# Altium schematic sheet 1_MICROCONTROLADOR.SchDoc
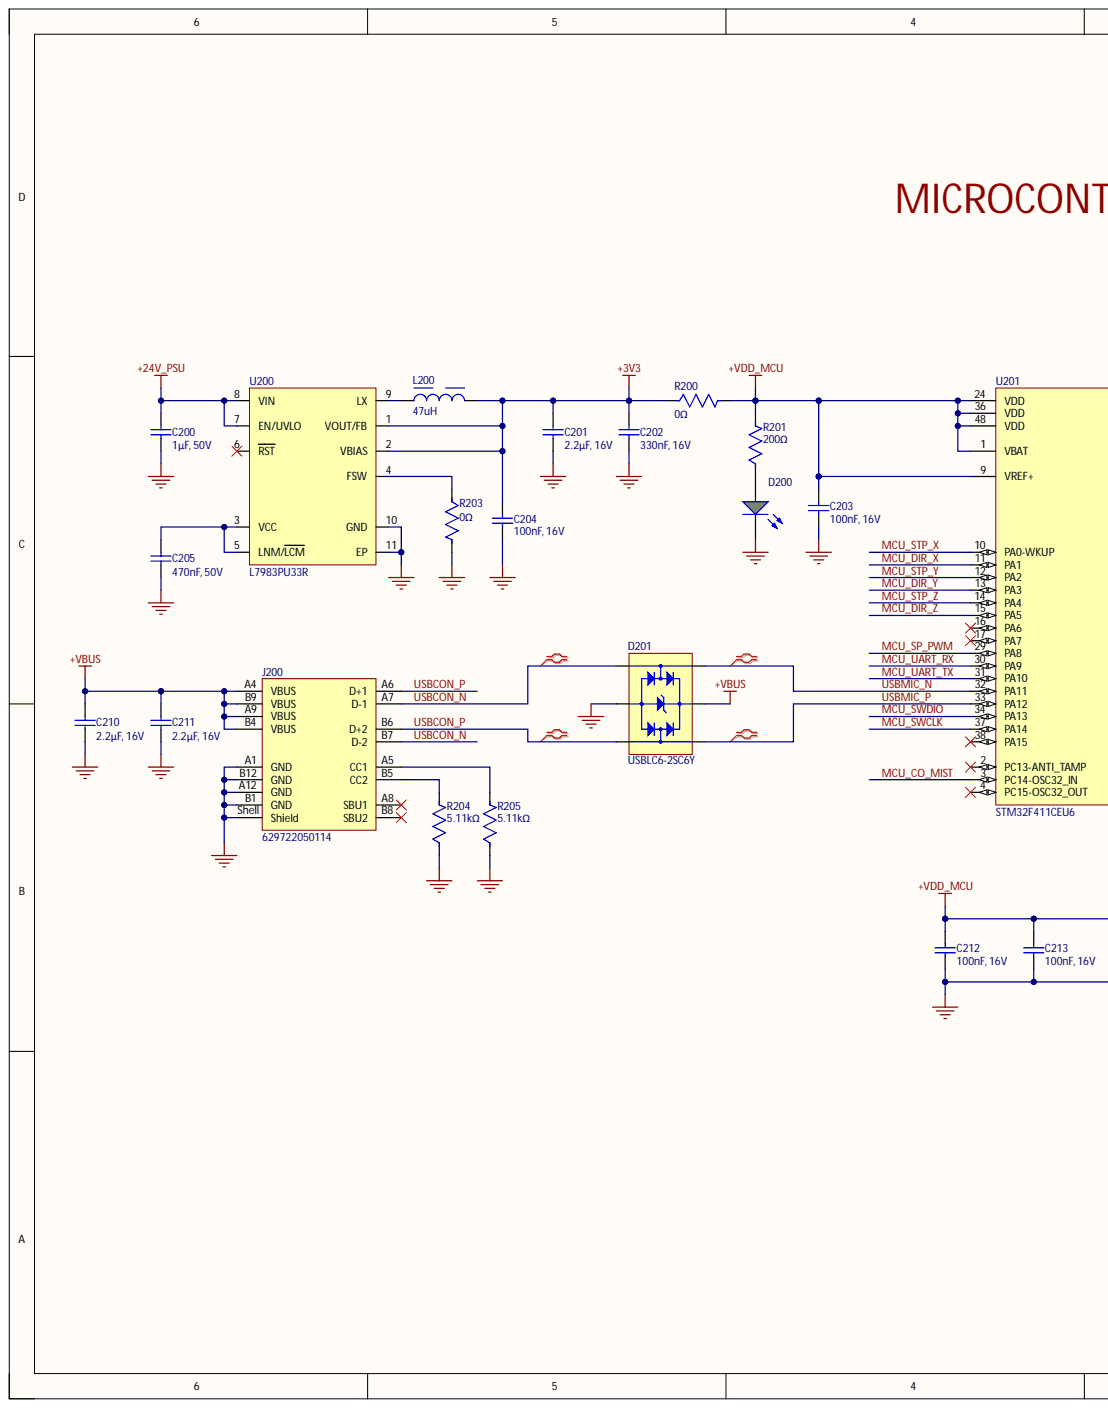
[diagram p0: 1_MICROCONTROLADOR.SchDoc, left]
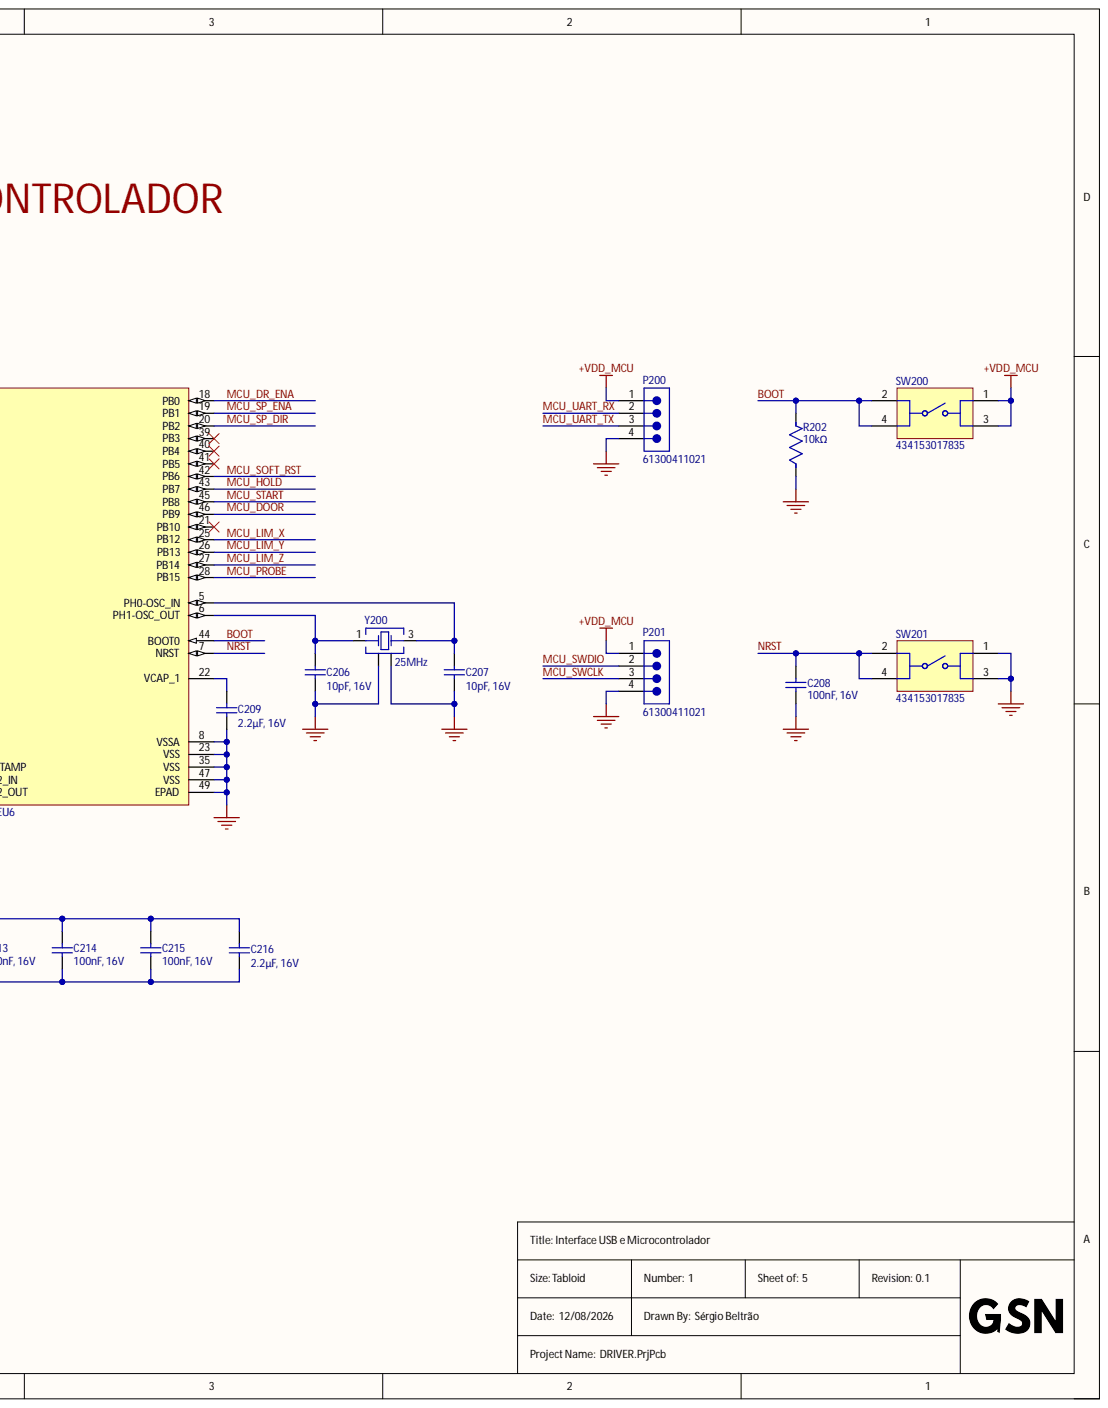
[diagram p1: 1_MICROCONTROLADOR.SchDoc, right]

## Parts
C200  1μF, 50V  Rated Voltage (DC): 50V  [WCAP-CSGP_0805_L2W1.25H1.25]
C201  2.2μF, 16V  [WCAP-CSGP_0603_L1.6W0.8H0.8_Wave]
C202  330nF, 16V  Rated Voltage (DC): 16V  [WCAP-CSGP_0603_L1.6W0.8H0.8_Wave]
C203  100nF, 16V  [WCAP-CSGP_0603_L1.6W0.8H0.8_Wave]
C204  100nF, 16V  [WCAP-CSGP_0603_L1.6W0.8H0.8_Wave]
C205  470nF, 50V  [WCAP-CSGP_0805_L2W1.25H1.25]
C206  10pF, 16V  Rated Voltage (DC): 16V  [WCAP-CSGP_0603_L1.6W0.8H0.8_Wave]
C207  10pF, 16V  Rated Voltage (DC): 16V  [WCAP-CSGP_0603_L1.6W0.8H0.8_Wave]
C208  100nF, 16V  [WCAP-CSGP_0603_L1.6W0.8H0.8_Wave]
C209  2.2μF, 16V  Rated Voltage (DC): 16V  [WCAP-CSGP_0603_L1.6W0.8H0.8_Wave]
C210  2.2μF, 16V  [WCAP-CSGP_0603_L1.6W0.8H0.8_Wave]
C211  2.2μF, 16V  Rated Voltage (DC): 16V  [WCAP-CSGP_0603_L1.6W0.8H0.8_Wave]
C212  100nF, 16V  [WCAP-CSGP_0603_L1.6W0.8H0.8_Wave]
C213  100nF, 16V  Rated Voltage (DC): 16V  [WCAP-CSGP_0603_L1.6W0.8H0.8_Wave]
C214  100nF, 16V  Rated Voltage (DC): 16V  [WCAP-CSGP_0603_L1.6W0.8H0.8_Wave]
C215  100nF, 16V  Rated Voltage (DC): 16V  [WCAP-CSGP_0603_L1.6W0.8H0.8_Wave]
C216  2.2μF, 16V  Rated Voltage (DC): 16V  [WCAP-CSGP_0603_L1.6W0.8H0.8_Wave]
D200  Bright Green, 2V, 140deg  [WL-SMCW_0603_150060xx75000]  (value not drawn)
D201  USBLC6-2SC6Y  [SOT-23-6]
J200  629722050114  [629722050114]
L200  47uH  [WE-MAIA_3015]
P200  61300411021  [61300411021]
P201  61300411021  [61300411021]
R200  0Ω  [0805-WAVE]
R201  200Ω  [0603-WAVE]
R202  10kΩ  [0603-WAVE]
R203  0Ω  [0603-WAVE]
R204  5.11kΩ  [0603-WAVE]
R205  5.11kΩ  [0603-WAVE]
SW200  434153017835  [434153017835]
SW201  434153017835  [434153017835]
U200  L7983PU33R  [10-WFDFN-EP]
U201  STM32F411CEU6  [UFQFN-48-7X7_EXPOSED_PAD]
Y200  25MHz  [WE-XTAL_IQXC-42]

## Nets
+3V3: C201.2 C202.2 C204.2 L200.2 R200.1 U200.1(VOUT/FB) U200.2(VBIAS)
+24V_PSU: C200.2 U200.7(EN/UVLO) U200.8(VIN)
+VBUS: C210.2 C211.2 D201.5 J200.A4(VBUS) J200.A9(VBUS) J200.B4(VBUS) J200.B9(VBUS)
+VDD_MCU: C203.2 C212.2 C213.2 C214.2 C215.2 C216.2 P200.1 P201.1 R200.2 R201.2 SW200.1 SW200.3 U201.1(VBAT) U201.9(VREF+) U201.24(VDD) U201.36(VDD) U201.48(VDD)
GND: C200.1 C201.1 C202.1 C203.1 C204.1 C205.1 C206.1 C207.1 C208.1 C209.1 C210.1 C211.1 C212.1 C213.1 C214.1 C215.1 C216.1 D200.1 D201.2 J200.A1(GND) J200.A12(GND) J200.B1(GND) J200.B12(GND) J200.Shell(Shield) P200.4 P201.4 R202.1 R203.2 R204.1 R205.1 SW201.1 SW201.3 U200.10(GND) U200.11(EP) U201.8(VSSA) U201.23(VSS) U201.35(VSS) U201.47(VSS) U201.49(EPAD) Y200.2 Y200.4
BOOT: R202.2 SW200.2 SW200.4 U201.44(BOOT0)
MCU_CO_MIST: U201.3(PC14-OSC32_IN)
MCU_DIR_X: U201.11(PA1)
MCU_DIR_Y: U201.13(PA3)
MCU_DIR_Z: U201.15(PA5)
MCU_DOOR: U201.46(PB9)
MCU_DR_ENA: U201.18(PB0)
MCU_HOLD: U201.43(PB7)
MCU_LIM_X: U201.25(PB12)
MCU_LIM_Y: U201.26(PB13)
MCU_LIM_Z: U201.27(PB14)
MCU_PROBE: U201.28(PB15)
MCU_SOFT_RST: U201.42(PB6)
MCU_SP_DIR: U201.20(PB2)
MCU_SP_ENA: U201.19(PB1)
MCU_SP_PWM: U201.29(PA8)
MCU_START: U201.45(PB8)
MCU_STP_X: U201.10(PA0-WKUP)
MCU_STP_Y: U201.12(PA2)
MCU_STP_Z: U201.14(PA4)
MCU_SWCLK: P201.3 U201.37(PA14)
MCU_SWDIO: P201.2 U201.34(PA13)
MCU_UART_RX: P200.2 U201.30(PA9)
MCU_UART_TX: P200.3 U201.31(PA10)
NRST: C208.2 SW201.2 SW201.4 U201.7(NRST)
USBCON_N: D201.1 J200.A7(D-1) J200.B7(D-2)
USBCON_P: D201.3 J200.A6(D+1) J200.B6(D+2)
USBMIC_N: D201.6 U201.32(PA11)
USBMIC_P: D201.4 U201.33(PA12)
NetC205_2: C205.2 U200.3(VCC) U200.5(LNM/LCM)
NetC206_2: C206.2 U201.6(PH1-OSC_OUT) Y200.1
NetC207_2: C207.2 U201.5(PH0-OSC_IN) Y200.3
NetC209_2: C209.2 U201.22(VCAP_1)
NetD200_2: D200.2 R201.1
NetJ200_A5: J200.A5(CC1) R205.2
NetJ200_B5: J200.B5(CC2) R204.2
NetL200_1: L200.1 U200.9(LX)
NetR203_1: R203.1 U200.4(FSW)

Not connected: J200 A8(SBU1) B8(SBU2); U200 6(RST); U201 2(PC13-ANTI_TAMP) 4(PC15-OSC32_OUT) 16(PA6) 17(PA7) 21(PB10) 38(PA15) 39(PB3) 40(PB4) 41(PB5)

## Library data of the parts
C200 = 885012207103R: WCAP-CSGP Multilayer Ceramic Chip Capacitor, General Purpose, size 0805, 1μF, 50V; Manufacturer: Wurth Elektronik; Capacitance: 1uF; Capacitance Tolerance: +/-10%; Case/Size Code: 0805; Ceramic Type: X7R; Database Table Name: Capacitors; Dissipation Factor: 10%; Insulation Resistance: 0.1Gohm; Technical Reference: X7R0805105K050DFCT20000; Category: Multilayer Ceramic Chip Capacitors; Component Kind: Standard; ComponentLink1Description: Website Link; ComponentLink1URL: https://www.we-online.com/redexpert/article/885012207103R?ad; ComponentLink2Description: Datasheet Link; ComponentLink2URL: https://www.we-online.com/redexpert/spec/885012207103R?ad; Height: 1.25mm; Length: 2mm; Library Name: WE - Passive Components.DbLib; Manufacturer Part Number: 885012207103R; Match Code: WCAP-CSGP; Mount: Surface Mount; Operating Temperature Max: 125degC; Operating Temperature Min: -55degC; Packaging: Tape and Reel; Simulation: 0805_885012207103R_1uF; Width: 1.25mm
C201 C209-C211 C216 = 885012106029: WCAP-CSGP Multilayer Ceramic Chip Capacitor, General Purpose, size 0603, 2.2μF, 16V; Manufacturer: Wurth Elektronik; Capacitance: 2.2uF; Capacitance Tolerance: +/-10%; Case/Size Code: 0603; Ceramic Type: X5R; Database Table Name: Capacitors; Dissipation Factor: 10%; Insulation Resistance: 0.05Gohm; Technical Reference: X5R0603225K016DFCT10000; Category: Multilayer Ceramic Chip Capacitors; Component Kind: Standard; ComponentLink1Description: Website Link; ComponentLink1URL: https://www.we-online.com/redexpert/article/885012106029?ad; ComponentLink2Description: Datasheet Link; ComponentLink2URL: https://www.we-online.com/redexpert/spec/885012106029?ad; Height: 0.8mm; Length: 1.6mm; Library Name: WE - Passive Components.DbLib; Manufacturer Part Number: 885012106029; Match Code: WCAP-CSGP; Mount: Surface Mount; Operating Temperature Max: 85degC; Operating Temperature Min: -55degC; Packaging: Tape and Reel; Simulation: 0603_885012106029_2.2uF; Width: 0.8mm
  C201 C210: Rated Voltage (DC): 16V
C202 = 885012206049: WCAP-CSGP Multilayer Ceramic Chip Capacitor, General Purpose, size 0603, 330nF, 16V; Manufacturer: Wurth Elektronik; Capacitance Tolerance: +/-10%; Case/Size Code: 0603; Ceramic Type: X7R; Database Table Name: Capacitors; Dissipation Factor: 5%; Insulation Resistance: 1.5Gohm; Technical Reference: X7R0603334K016DFCT10000; Capacitance: 330nF; Category: Multilayer Ceramic Chip Capacitors; Component Kind: Standard; ComponentLink1Description: Website Link; ComponentLink1URL: https://www.we-online.com/redexpert/article/885012206049?ad; ComponentLink2Description: Datasheet Link; ComponentLink2URL: https://www.we-online.com/redexpert/spec/885012206049?ad; Height: 0.8mm; Length: 1.6mm; Library Name: WE - Passive Components.DbLib; Manufacturer Part Number: 885012206049; Match Code: WCAP-CSGP; Mount: Surface Mount; Operating Temperature Max: 125degC; Operating Temperature Min: -55degC; Packaging: Tape and Reel; Simulation: 0603_885012206049_330nF; Width: 0.8mm
C203 C204 C208 C212-C215 = 885012206046: WCAP-CSGP Multilayer Ceramic Chip Capacitor, General Purpose, size 0603, 100nF, 16V; Manufacturer: Wurth Elektronik; Capacitance Tolerance: +/-10%; Case/Size Code: 0603; Ceramic Type: X7R; Database Table Name: Capacitors; Dissipation Factor: 3.5%; Insulation Resistance: 5Gohm; Technical Reference: X7R0603104K016DFCT10000; Capacitance: 100nF; Category: Multilayer Ceramic Chip Capacitors; Component Kind: Standard; ComponentLink1Description: Website Link; ComponentLink1URL: https://www.we-online.com/redexpert/article/885012206046?ad; ComponentLink2Description: Datasheet Link; ComponentLink2URL: https://www.we-online.com/redexpert/spec/885012206046?ad; Height: 0.8mm; Length: 1.6mm; Library Name: WE - Passive Components.DbLib; Manufacturer Part Number: 885012206046; Match Code: WCAP-CSGP; Mount: Surface Mount; Operating Temperature Max: 125degC; Operating Temperature Min: -55degC; Packaging: Tape and Reel; Simulation: 0603_885012206046_100nF; Width: 0.8mm
  C203 C204 C208 C212: Rated Voltage (DC): 16V
C205 = 885012207102: WCAP-CSGP Multilayer Ceramic Chip Capacitor, General Purpose, size 0805, 470nF, 50V; Manufacturer: Wurth Elektronik; Capacitance Tolerance: +/-10%; Case/Size Code: 0805; Ceramic Type: X7R; Database Table Name: Capacitors; Dissipation Factor: 3%; Insulation Resistance: 1.1Gohm; Technical Reference: X7R0805474K050DFCT10000; Capacitance: 470nF; Category: Multilayer Ceramic Chip Capacitors; Component Kind: Standard; ComponentLink1Description: Website Link; ComponentLink1URL: https://www.we-online.com/redexpert/article/885012207102?ad; ComponentLink2Description: Datasheet Link; ComponentLink2URL: https://www.we-online.com/redexpert/spec/885012207102?ad; Height: 1.25mm; Length: 2mm; Library Name: WE - Passive Components.DbLib; Manufacturer Part Number: 885012207102; Match Code: WCAP-CSGP; Mount: Surface Mount; Operating Temperature Max: 125degC; Operating Temperature Min: -55degC; Packaging: Tape and Reel; Rated Voltage (DC): 50V; Simulation: 0805_885012207102_470nF; Width: 1.25mm
C206 C207 = 885012006017: WCAP-CSGP Multilayer Ceramic Chip Capacitor, General Purpose, size 0603, 10pF, 16V; Manufacturer: Wurth Elektronik; Capacitance Tolerance: +/-5%; Case/Size Code: 0603; Ceramic Type: NP0; Database Table Name: Capacitors; Insulation Resistance: 10Gohm; Q Factor: 600; Technical Reference: NP00603100J016DFCT10000; Capacitance: 10pF; Category: Multilayer Ceramic Chip Capacitors; Component Kind: Standard; ComponentLink1Description: Website Link; ComponentLink1URL: https://www.we-online.com/redexpert/article/885012006017?ad; ComponentLink2Description: Datasheet Link; ComponentLink2URL: https://www.we-online.com/redexpert/spec/885012006017?ad; Height: 0.8mm; Length: 1.6mm; Library Name: WE - Passive Components.DbLib; Manufacturer Part Number: 885012006017; Match Code: WCAP-CSGP; Mount: Surface Mount; Operating Temperature Max: 125degC; Operating Temperature Min: -55degC; Packaging: Tape and Reel; Simulation: 0603_885012006017_10pF; Width: 0.8mm
D200 = 150060VS75000: WL-SMCW Mono-color Chip LED Waterclear, SMT, size 0603, Bright Green, 2V, 140°; Manufacturer: Wurth Elektronik; Case/Size Code: 0603; Chip Technology: AlInGaP; Database Table Name: LEDs; Dominant Wavelength: 570nm; Luminous Intensity: 40mcd; Peak Wavelength: 572nm; Category: Signal LEDs; Component Kind: Standard; ComponentLink1Description: Website Link; ComponentLink1URL: https://www.we-online.com/redexpert/article/150060VS75000?ad; ComponentLink2Description: Datasheet Link; ComponentLink2URL: https://www.we-online.com/redexpert/spec/150060VS75000?ad; Emitting Color: Bright Green; Forward Voltage: 2V; Height: 0.7mm; Length: 1.6mm; Library Name: WE - Optoelectronic Components.DbLib; Manufacturer Part Number: 150060VS75000; Match Code: WL-SMCW; Mount: Surface Mount; Operating Temperature Max: 85degC; Operating Temperature Min: -40degC; Packaging: Tape and Reel; Simulation: 0603_150060VS75000; Viewing Angle: 140deg; Width: 0.8mm
D201 = USBLC6-2SC6Y: TVS DIODE 5.25VWM 17VC SOT23-6; Manufacturer: STMicroelectronics; Breakdown Voltage: 6V; Clamping Voltage: 17V; Direction: Unidirectional; Element Configuration: Dual; ESD Protection: Yes; ESR: 330Ω; Forward Voltage: 860mV; Leakage Current: 150nA; Max Junction Temperature (Tj): 150°C; Number of Bidirectional Channels: 2; Number of Elements: 1; Operating Supply Voltage: 1.1V; Peak Pulse Current: 5A; Reverse Standoff Voltage: 5.25V; Test Current: 1mA; Value: 2.5pF; Case/Package: SOT-23-6; Category: TVS Diodes; China RoHS: Compliant; Component Kind: Standard; ComponentLink1Description: https://datasheet.octopart.com:443/USBLC6-2SC6Y-STMicroelectronics-datasheet-12533815.pdf?src-supplier=ODG+(Origin+Data+Global); ComponentLink1URL: https://datasheet.octopart.com:443/USBLC6-2SC6Y-STMicroelectronics-datasheet-12533815.pdf?src-supplier=ODG+(Origin+Data+Global); ComponentLink2Description: https://datasheet.octopart.com:443/USBLC6-2SC6Y-STMicroelectronics-datasheet-13733956.pdf?src-supplier=STMicroelectronics; ComponentLink2URL: https://datasheet.octopart.com:443/USBLC6-2SC6Y-STMicroelectronics-datasheet-13733956.pdf?src-supplier=STMicroelectronics; ComponentLink3Description: https://datasheet.octopart.com:443/USBLC6-2SC6Y-STMicroelectronics-datasheet-10412581.pdf?src-supplier=Factory+Futures; ComponentLink3URL: https://datasheet.octopart.com:443/USBLC6-2SC6Y-STMicroelectronics-datasheet-10412581.pdf?src-supplier=Factory+Futures; ComponentLink4Description: https://datasheet.octopart.com:443/USBLC6-2SC6Y-STMicroelectronics-datasheet-525477.pdf?src-supplier=Factory+Futures; ComponentLink4URL: https://datasheet.octopart.com:443/USBLC6-2SC6Y-STMicroelectronics-datasheet-525477.pdf?src-supplier=Factory+Futures; Depth: 1.75mm; Height: 1.45mm; Lead Free: Lead Free; Length: 3mm; Library Name: TVS-Diodes.SchLib; Lifecycle Status: Production; Manufacturer Part Number: USBLC6-2SC6Y; Max Operating Temperature: 150°C; Max Reverse Leakage Current: 150nA; Min Breakdown Voltage: 6V; Min Operating Temperature: -40°C; Mounting Technology: Surface Mount; Number of Channels: 2; Number of Terminals: 6; Packaging: Tape and Reel; Peak Reverse Current: 150nA; Pins: 6; Polarity: Unidirectional; Power Line Protection: Yes; Pricing: 1=0.46, 10=0.298, 100=0.211, 500=0.164, 1000=0.144 (USD); Radiation Hardening: No; REACH SVHC: Yes; RoHS: Compliant; Schedule B: 8536300000, 8536300000|8536300000|8536300000|8536300000|8536300000; Stock: 64605; Supplier: DigiKey; Supplier Part Number: 497-11882-1-ND; Termination: SMD/SMT; Width: 1.75mm
J200 = 629722050114: WR-COM USB 2.0 Vertical SMT; Manufacturer: Wurth Elektronik; Database Table Name: Connectors; Gender: Receptacle; Interface Type: Type C; Match Code: WR-USB; Number of Ports: 1; Rated Current: 5; Working Voltage: 48V (DC); Application: USB 2.0; Category: Input/Output Connector; Component Kind: Standard; ComponentLink1Description: Website Link; ComponentLink1URL: https://www.we-online.com; ComponentLink2Description: Datasheet Link; ComponentLink2URL: https://www.we-online.com/components/products/datasheet/629722050114; Library Name: WE - Electromechanical Components.DbLib; Manufacturer Part Number: 629722050114; Mount: Surface Mount; Number of Pins: 16; Operating Temperature Max: 85degC; Operating Temperature Min: -40degC; Orientation: Vertical; Packaging: Tape and Reel
L200 = 78438335470: WE-MAIA SMT Power Inductor, size 3015, 47uH, 0.5A, 2300mohm; Manufacturer: Wurth Elektronik; Case/Size Code: 3015; Database Table Name: Inductors; Saturation Current @ 10%: 0.75A; Saturation Current @ 30%: 1.5A; Self Resonant Frequency: 8MHz; Automotive: Yes; Category: Power Inductors; Component Kind: Standard; ComponentLink1Description: Website Link; ComponentLink1URL: https://www.we-online.com/redexpert/article/78438335470?ad; ComponentLink2Description: Datasheet Link; ComponentLink2URL: https://www.we-online.com/redexpert/spec/78438335470?ad; DC Resistance Max: 2300mohm; Height: 1.5mm; Inductance: 47uH; Length: 3mm; Library Name: WE - Passive Components.DbLib; Manufacturer Part Number: 78438335470; Match Code: WE-MAIA; Mount: Surface Mount; Operating Temperature Max: 125degC; Operating Temperature Min: -40degC; Packaging: Tape and Reel; Rated Current: 0.5A; Simulation: 3015_78438335470_47u; Width: 3mm
P200 P201 = 61300411021: WR-PHD Pin Header, THT, Angled, pitch 2.54mm, 1 Row, 4P; Manufacturer: Wurth Elektronik; Contact Resistance: 20mOhm; Database Table Name: Connectors; Rated Current: 3A; Withstanding Voltage: 500V; Working Voltage: 250V; Category: Board-to-Board Connectors; Component Kind: Standard; ComponentLink1Description: Website Link; ComponentLink1URL: https://www.we-online.com/redexpert/article/61300411021?ad; ComponentLink2Description: Datasheet Link; ComponentLink2URL: https://www.we-online.com/redexpert/spec/61300411021?ad; Gender: Pin Header; Lead Pitch: 2.54mm; Library Name: WE - Electromechanical Components.DbLib; Manufacturer Part Number: 61300411021; Match Code: WR-PHD; Mount: Through Hole; Number of Pins: 4; Number of Rows: 1; Operating Temperature Max: 105degC; Operating Temperature Min: -40degC; Orientation: Angled; Packaging: Bag
R200 = RC0805FR-070RL: RES 0 OHM 1% 1/8W 0805; Manufacturer: Yageo Group; Power Dissipation: 125mW; Temperature Coefficient: 100ppm/°C; Voltage Rating: 150V; Case/Package: 0805; Category: Chip SMD Resistors; China RoHS: Non-Compliant; Component Kind: Standard; ComponentLink1Description: https://datasheet.octopart.com:443/RC0805FR-070RL-Yageo-datasheet-127823281.pdf?src-supplier=Upverter; ComponentLink1URL: https://datasheet.octopart.com:443/RC0805FR-070RL-Yageo-datasheet-127823281.pdf?src-supplier=Upverter; ComponentLink2Description: https://datasheet.octopart.com:443/RC0805FR-070RL-Yageo-datasheet-17879891.pdf?src-supplier=IHS; ComponentLink2URL: https://datasheet.octopart.com:443/RC0805FR-070RL-Yageo-datasheet-17879891.pdf?src-supplier=IHS; ComponentLink3Description: https://datasheet.octopart.com:443/RC0805FR-070RL-Yageo-datasheet-8330313.pdf?src-supplier=Burklin+Elektronik; ComponentLink3URL: https://datasheet.octopart.com:443/RC0805FR-070RL-Yageo-datasheet-8330313.pdf?src-supplier=Burklin+Elektronik; ComponentLink4Description: https://datasheet.octopart.com:443/RC0805FR-070RL-Yageo-datasheet-164759695.pdf?src-supplier=Future+Electronics; ComponentLink4URL: https://datasheet.octopart.com:443/RC0805FR-070RL-Yageo-datasheet-164759695.pdf?src-supplier=Future+Electronics; ComponentLink5Description: https://datasheet.octopart.com:443/RC0805FR-070RL-Yageo-datasheet-12592811.pdf?src-supplier=Future+Electronics; ComponentLink5URL: https://datasheet.octopart.com:443/RC0805FR-070RL-Yageo-datasheet-12592811.pdf?src-supplier=Future+Electronics; ComponentLink6Description: https://datasheet.octopart.com:443/RC0805FR-070RL-Yageo-datasheet-8324252.pdf?src-supplier=Future+Electronics; ComponentLink6URL: https://datasheet.octopart.com:443/RC0805FR-070RL-Yageo-datasheet-8324252.pdf?src-supplier=Future+Electronics; ComponentLink7Description: https://datasheet.octopart.com:443/RC0805FR-070RL-Yageo-datasheet-141265859.pdf?src-supplier=TME; ComponentLink7URL: https://datasheet.octopart.com:443/RC0805FR-070RL-Yageo-datasheet-141265859.pdf?src-supplier=TME; ComponentLink8Description: https://datasheet.octopart.com/RC0805FR-070RL-Yageo-datasheet-73766026.pdf; ComponentLink8URL: https://datasheet.octopart.com/RC0805FR-070RL-Yageo-datasheet-73766026.pdf; ComponentLink9Description: http://sigma.octopart.com:80/conflict_mineral_statement/Yageo-company-3.pdf?src-supplier=Compliance+Statements; ComponentLink9URL: http://sigma.octopart.com:80/conflict_mineral_statement/Yageo-company-3.pdf?src-supplier=Compliance+Statements; ComponentLink10Description: http://sigma.octopart.com:80/rohs_statement/Yageo-company-2.pdf?src-supplier=Compliance+Statements; ComponentLink10URL: http://sigma.octopart.com:80/rohs_statement/Yageo-company-2.pdf?src-supplier=Compliance+Statements; Composition: Thick Film; Contact Plating: Tin; Depth: 1.25mm; Height: 500µm; Lead Free: Lead Free; Length: 2mm; Library Name: Resistors-0805-E96-Yageo.SchLib; Lifecycle Status: Production; Manufacturer Part Number: RC0805FR-070RL; Max Operating Temperature: 155°C; Military Standard: Not; Min Operating Temperature: -55°C; Mounting Technology: Surface Mount; Number of Terminals: 2; Power Rating: 125mW; Pricing: 1=0.1, 10=0.017, 25=0.0144, 50=0.0124, 100=0.0109, 250=0.00916, 500=0.00808, 1000=0.00715 (USD); Radiation Hardening: No; REACH SVHC: Yes; RoHS: Compliant; Stock: 748818; Supplier: DigiKey; Supplier Part Number: 311-0.0CRCT-ND; Tolerance: 1%; Value: 0Ω; Width: 1.25mm
R201 = RC0603FR-07200RL: RES 200 OHM 1% 1/10W 0603; Manufacturer: Yageo Group; Max Power Dissipation: 100mW; Temperature Coefficient: ±200ppm/°C; Voltage Rating: 75V; Voltage Rating (AC): 50V; Case Code (Imperial): 0603; Case Code (Metric): 1608; Case/Package: 0603; Category: Chip SMD Resistors; China RoHS: Non-Compliant; Component Kind: Standard; Component Link Description: https://www.yageogroup.com/content/datasheet/asset/file/PYU-RC_GROUP_51_ROHS_L; Component Link URL: https://www.yageogroup.com/content/datasheet/asset/file/PYU-RC_GROUP_51_ROHS_L; Composition: Thick Film; Contact Plating: Tin; Datasheet: https://www.yageogroup.com/content/datasheet/asset/file/PYU-RC_GROUP_51_ROHS_L; Depth: 800µm; Features: Moisture Resistant; Height: 450µm; Lead Free: Lead Free; Length: 1.6mm; Library Name: Resistors-0603-E96-Yageo.SchLib; Lifecycle Status: Production; Manufacturer Part Number: RC0603FR-07200RL; Max Operating Temperature: 155°C; Military Standard: Not; Min Operating Temperature: -55°C; Mounting Technology: Surface Mount; Number of Terminals: 2; Number of Terminations: 2; Packaging: Tape & Reel; Power Dissipation: 100mW; Power Rating: 100mW; Radiation Hardening: No; REACH SVHC: Yes; RoHS: Compliant; Supplier: DigiKey; Supplier Part Number: 311-200HRCT-ND; Termination: SMD/SMT; Tolerance: 1%; Value: 200Ω; Voltage Rating (DC): 50V; Weight: 2.012816mg; Width: 800µm; Working Voltage: 75V
R202 = RC0603FR-0710KL: RES 10K OHM 1% 1/10W 0603; Manufacturer: Yageo Group; Max Power Dissipation: 100mW; Temperature Coefficient: ±200ppm/°C; Voltage Rating: 75V; Voltage Rating (AC): 50V; Case Code (Imperial): 0603; Case Code (Metric): 1608; Case/Package: 0603; Category: Chip SMD Resistors; China RoHS: Non-Compliant; Component Kind: Standard; Component Link Description: https://www.yageogroup.com/content/datasheet/asset/file/PYU-RC_GROUP_51_ROHS_L; Component Link URL: https://www.yageogroup.com/content/datasheet/asset/file/PYU-RC_GROUP_51_ROHS_L; Composition: Thick Film; Contact Plating: Tin; Datasheet: https://www.yageogroup.com/content/datasheet/asset/file/PYU-RC_GROUP_51_ROHS_L; Depth: 800µm; Features: Moisture Resistant; Height: 450µm; Lead Free: Lead Free; Length: 1.6mm; Library Name: Resistors-0603-E96-Yageo.SchLib; Lifecycle Status: Production; Manufacturer Part Number: RC0603FR-0710KL; Max Operating Temperature: 155°C; Military Standard: Not; Min Operating Temperature: -55°C; Mounting Technology: Surface Mount; Number of Terminals: 2; Number of Terminations: 2; Packaging: Tape & Reel; Power Dissipation: 100mW; Power Rating: 100mW; Radiation Hardening: No; REACH SVHC: Yes; RoHS: Compliant; Supplier: DigiKey; Supplier Part Number: 311-10.0KHRCT-ND; Termination: SMD/SMT; Tolerance: 1%; Value: 10kΩ; Voltage Rating (DC): 50V; Weight: 2.012816mg; Width: 800µm; Working Voltage: 75V
R203 = RC0603FR-070RL: RES 0 OHM 1% 1/10W 0603; Manufacturer: Yageo Group; Max Power Dissipation: 100mW; Temperature Coefficient: ±200ppm/°C; Voltage Rating: 75V; Voltage Rating (AC): 50V; Case Code (Imperial): 0603; Case Code (Metric): 1608; Case/Package: 0603; Category: Chip SMD Resistors; China RoHS: Non-Compliant; Component Kind: Standard; Component Link Description: https://www.yageogroup.com/content/datasheet/asset/file/PYU-RC_GROUP_51_ROHS_L; Component Link URL: https://www.yageogroup.com/content/datasheet/asset/file/PYU-RC_GROUP_51_ROHS_L; Composition: Thick Film; Contact Plating: Tin; Datasheet: https://www.yageogroup.com/content/datasheet/asset/file/PYU-RC_GROUP_51_ROHS_L; Depth: 800µm; Features: Moisture Resistant; Height: 450µm; Lead Free: Lead Free; Length: 1.6mm; Library Name: Resistors-0603-E96-Yageo.SchLib; Lifecycle Status: Production; Manufacturer Part Number: RC0603FR-070RL; Max Operating Temperature: 155°C; Military Standard: Not; Min Operating Temperature: -55°C; Mounting Technology: Surface Mount; Number of Terminals: 2; Number of Terminations: 2; Packaging: Tape & Reel; Power Dissipation: 100mW; Power Rating: 100mW; Radiation Hardening: No; REACH SVHC: Yes; RoHS: Compliant; Supplier: DigiKey; Supplier Part Number: 311-0.0HRCT-ND; Termination: SMD/SMT; Tolerance: 1%; Value: 0Ω; Voltage Rating (DC): 50V; Weight: 2.012816mg; Width: 800µm; Working Voltage: 75V
R204 R205 = RC0603FR-075K11L: RES 5.11K OHM 1% 1/10W 0603; Manufacturer: Yageo Group; Max Power Dissipation: 100mW; Temperature Coefficient: ±200ppm/°C; Voltage Rating: 75V; Voltage Rating (AC): 50V; Case Code (Imperial): 0603; Case Code (Metric): 1608; Case/Package: 0603; Category: Chip SMD Resistors; China RoHS: Non-Compliant; Component Kind: Standard; Component Link Description: https://www.yageogroup.com/content/datasheet/asset/file/PYU-RC_GROUP_51_ROHS_L; Component Link URL: https://www.yageogroup.com/content/datasheet/asset/file/PYU-RC_GROUP_51_ROHS_L; Composition: Thick Film; Contact Plating: Tin; Datasheet: https://www.yageogroup.com/content/datasheet/asset/file/PYU-RC_GROUP_51_ROHS_L; Depth: 800µm; Features: Moisture Resistant; Height: 450µm; Lead Free: Lead Free; Length: 1.6mm; Library Name: Resistors-0603-E96-Yageo.SchLib; Lifecycle Status: Production; Manufacturer Part Number: RC0603FR-075K11L; Max Operating Temperature: 155°C; Military Standard: Not; Min Operating Temperature: -55°C; Mounting Technology: Surface Mount; Number of Terminals: 2; Number of Terminations: 2; Packaging: Tape & Reel; Power Dissipation: 100mW; Power Rating: 100mW; Radiation Hardening: No; REACH SVHC: Yes; RoHS: Compliant; Supplier: DigiKey; Supplier Part Number: 311-5.11KHRCT-ND; Termination: SMD/SMT; Tolerance: 1%; Value: 5.11kΩ; Voltage Rating (DC): 50V; Weight: 2.012816mg; Width: 800µm; Working Voltage: 75V
SW200 SW201 = 434153017835: WS-TASV J-Bend SMT Tact Switch, 3.5mm x 2.9mm x 1.7mm, SPST-NO, 350gf, 12V, 50mA; Manufacturer: Wurth Elektronik; Database Table Name: Switches; Washable: No; Category: Tact Switch; Circuit: SPST-NO; Component Kind: Standard; ComponentLink1Description: Website Link; ComponentLink1URL: https://www.we-online.com; ComponentLink2Description: Datasheet Link; ComponentLink2URL: https://www.we-online.com/components/products/datasheet/434153017835; Contact Material: Stainless Steel; Contact Plating: Silver; Height: 1.7mm; Length: 3.5mm; Library Name: WE - Electromechanical Components.DbLib; Manufacturer Part Number: 434153017835; Match Code: WS-TASV; Mount: Surface Mount; Operating Temperature Max: 85degC; Operating Temperature Min: -40degC; Operation Force: 350gf; Packaging: Tape and Reel; Rated Current: 50mA; Rated Voltage (DC): 12V; Terminal Material: Copper Alloy; Terminal Plating: Silver; Width: 2.9mm
U200 = L7983PU33R: IC REG BUCK 3.3V 300MA 10DFN; Manufacturer: STMicroelectronics; Max Output Voltage: 60V; Max Switching Frequency: 2.42MHz; Min Input Voltage: 3.5V; Min Output Voltage: 850mV; Nominal Supply Voltage: 24V; Number of Circuits: 1; Quiescent Current: 10µA; Synchronous Rectifier: Yes; Category: Voltage Regulators - Switching; China RoHS: Compliant; Component Kind: Standard; Country of Origin: Mainland China; Export Control Classification Number (ECCN) Code: EAR99; Harmonized Tariff Schedule (HTS) Code: 8542.39.00.60; Height - Seated (Max): 800µm; Introduction Date: 2020-10-06; Length: 3mm; Library Name: Voltage-Regulators.SchLib; Lifecycle Status: Production; Manufacturer Part Number: L7983PU33R; Max Operating Temperature: 150°C; Max Output current: 300mA; Max Supply Voltage: 60V; Min Operating Temperature: -40°C; Nominal Output Voltage: 3.3V; Number of Terminals: 10; Output Current: 300mA; Packaging: Tape and Reel; Pricing: 1=2.69, 10=2.001, 25=1.83, 100=1.6411, 250=1.55108, 500=1.5155 (USD); REACH SVHC: Yes; RoHS: Compliant; Schedule B: 8542390000, 8542390000|8542390000|8542390000|8542390000|8542390000|8542390000|8542390000|8542390000|8542390000|8542390000|8542390000|8542390000|8542390000|8542390000|8542390000|8542390000|8542390000|8542390000|8542390000|8542390000|8542390000|8542390000|8542390000|85; Stock: 4366; Supplier: DigiKey; Supplier Part Number: 497-L7983PU33RCT-ND; Terminal Pitch: 500µm; Topology: Buck; Width: 3mm
U201 = STM32F411CEU6: IC MCU 32BIT 512KB FLSH 48UFQFPN; Manufacturer: STMicroelectronics; ADC Channels (Resolution): 10-Ch 12-Bit; Clock Frequency: 26MHz; Core Architecture: ARM Cortex-M4; Data Bus Width: 32b; EEPROM Memory Size: 0B; Frequency: 100MHz; Interface: SDIO, USB; Max Power Dissipation: 625mW; Max Supply Current: 34.5mA; Max Supply Voltage: 3.6V; Memory Format: FLASH; Min Supply Voltage: 1.7V; Nominal Supply Current: 1.8µA; Number of A/D Converters: 1; Number of ADC Channels: 16; Number of Channels: 10; Number of I2C Channels: 3; Number of I/Os: 36; Number of SPI Channels: 5; Number of Timers/Counters: 2; Operating Supply Voltage: 3.3V; Oscillator Type: Internal; Peripherals: POR; RAM Size: 128kB; Watchdog Timer: Yes; Case/Package: UFQFPN; Category: Microcontrollers; China RoHS: Compliant; Component Kind: Standard; ComponentLink1Description: https://datasheet.octopart.com:443/STM32F411CEU6-STMicroelectronics-datasheet-41919523.pdf?src-supplier=element14+APAC; ComponentLink1URL: https://datasheet.octopart.com:443/STM32F411CEU6-STMicroelectronics-datasheet-41919523.pdf?src-supplier=element14+APAC; ComponentLink2Description: https://datasheet.octopart.com:443/STM32F411CEU6-STMicroelectronics-datasheet-27102711.pdf?src-supplier=Upverter; ComponentLink2URL: https://datasheet.octopart.com:443/STM32F411CEU6-STMicroelectronics-datasheet-27102711.pdf?src-supplier=Upverter; ComponentLink3Description: https://datasheet.octopart.com:443/STM32F411CEU6-STMicroelectronics-datasheet-114750309.pdf?src-supplier=Newark; ComponentLink3URL: https://datasheet.octopart.com:443/STM32F411CEU6-STMicroelectronics-datasheet-114750309.pdf?src-supplier=Newark; ComponentLink4Description: https://datasheet.octopart.com:443/STM32F411CEU6-STMicroelectronics-datasheet-34219326.pdf?src-supplier=Newark; ComponentLink4URL: https://datasheet.octopart.com:443/STM32F411CEU6-STMicroelectronics-datasheet-34219326.pdf?src-supplier=Newark; ComponentLink5Description: https://datasheet.octopart.com:443/STM32F411CEU6-STMicroelectronics-datasheet-82511007.pdf?src-supplier=SHENGYU+ELECTRONICS; ComponentLink5URL: https://datasheet.octopart.com:443/STM32F411CEU6-STMicroelectronics-datasheet-82511007.pdf?src-supplier=SHENGYU+ELECTRONICS; Flash Memory Size: 512kB; Height - Seated (Max): 600µm; Lead Free: Lead Free; Length: 7mm; Library Name: Microcontrollers.SchLib; Lifecycle Status: Production; Manufacturer Part Number: STM32F411CEU6; Max Operating Temperature: 85°C; Memory Size: 512kB; Min Operating Temperature: -40°C; Mounting Technology: Surface Mount; Number of Interrupts: 16; Number of Programmable I/O: 36; Number of Terminals: 48; Number of USART Channels: 3; Packaging: Cut Tape; Pins: 48; Pricing: 1=6.18, 10=4.745, 25=4.386, 100=3.991, 260=3.79569, 520=3.68344, 1040=3.59105, 2600=3.49353 (USD); Radiation Hardening: No; REACH SVHC: Yes; RoHS: Compliant; Schedule B: 8542310000, 8542310000|8542310000|8542310000|8542310000|8542310000|8542310000|8542310000|8542310000|8542310000|8542310000|8542310000|8542310000|8542310000|8542310000|8542310000|8542310000|8542310000|8542310000|8542310000|8542310000|8542310000|8542310000|8542310000|85; Stock: 3816; Supplier: DigiKey; Supplier Part Number: 497-14907-ND; Terminal Pitch: 500µm; Width: 7mm
Y200 = 830069494: WE-XTAL Quartz Crystal, IQXC-42, 25MHz, +/-15ppm; Manufacturer: Wurth Elektronik; Database Table Name: Crystals & Oscillators; Frequency Stability: 20ppm; Load Capacitance: 10pF; Application: Processor, USB, 3G/4G/5G, Ethernet, Small Cell, PHY, PCI Express; Case/Size Code: IQXC-42; Category: Crystals; Component Kind: Standard; ComponentLink1Description: Website Link; ComponentLink1URL: https://www.we-online.com/redexpert/article/830069494?ad; ComponentLink2Description: Datasheet Link; ComponentLink2URL: https://www.we-online.com/redexpert/spec/830069494?ad; Frequency: 25MHz; Frequency Tolerance: +/-15ppm; Height: 0.5mm; Length: 2mm; Library Name: WE - Passive Components.DbLib; Manufacturer Part Number: 830069494; Match Code: WE-XTAL; Mount: Surface Mount; Operating Temperature Max: 85degC; Operating Temperature Min: -40degC; Packaging: Tape and Reel; Width: 1.6mm
